# Altium schematic sheet receiver.SchDoc
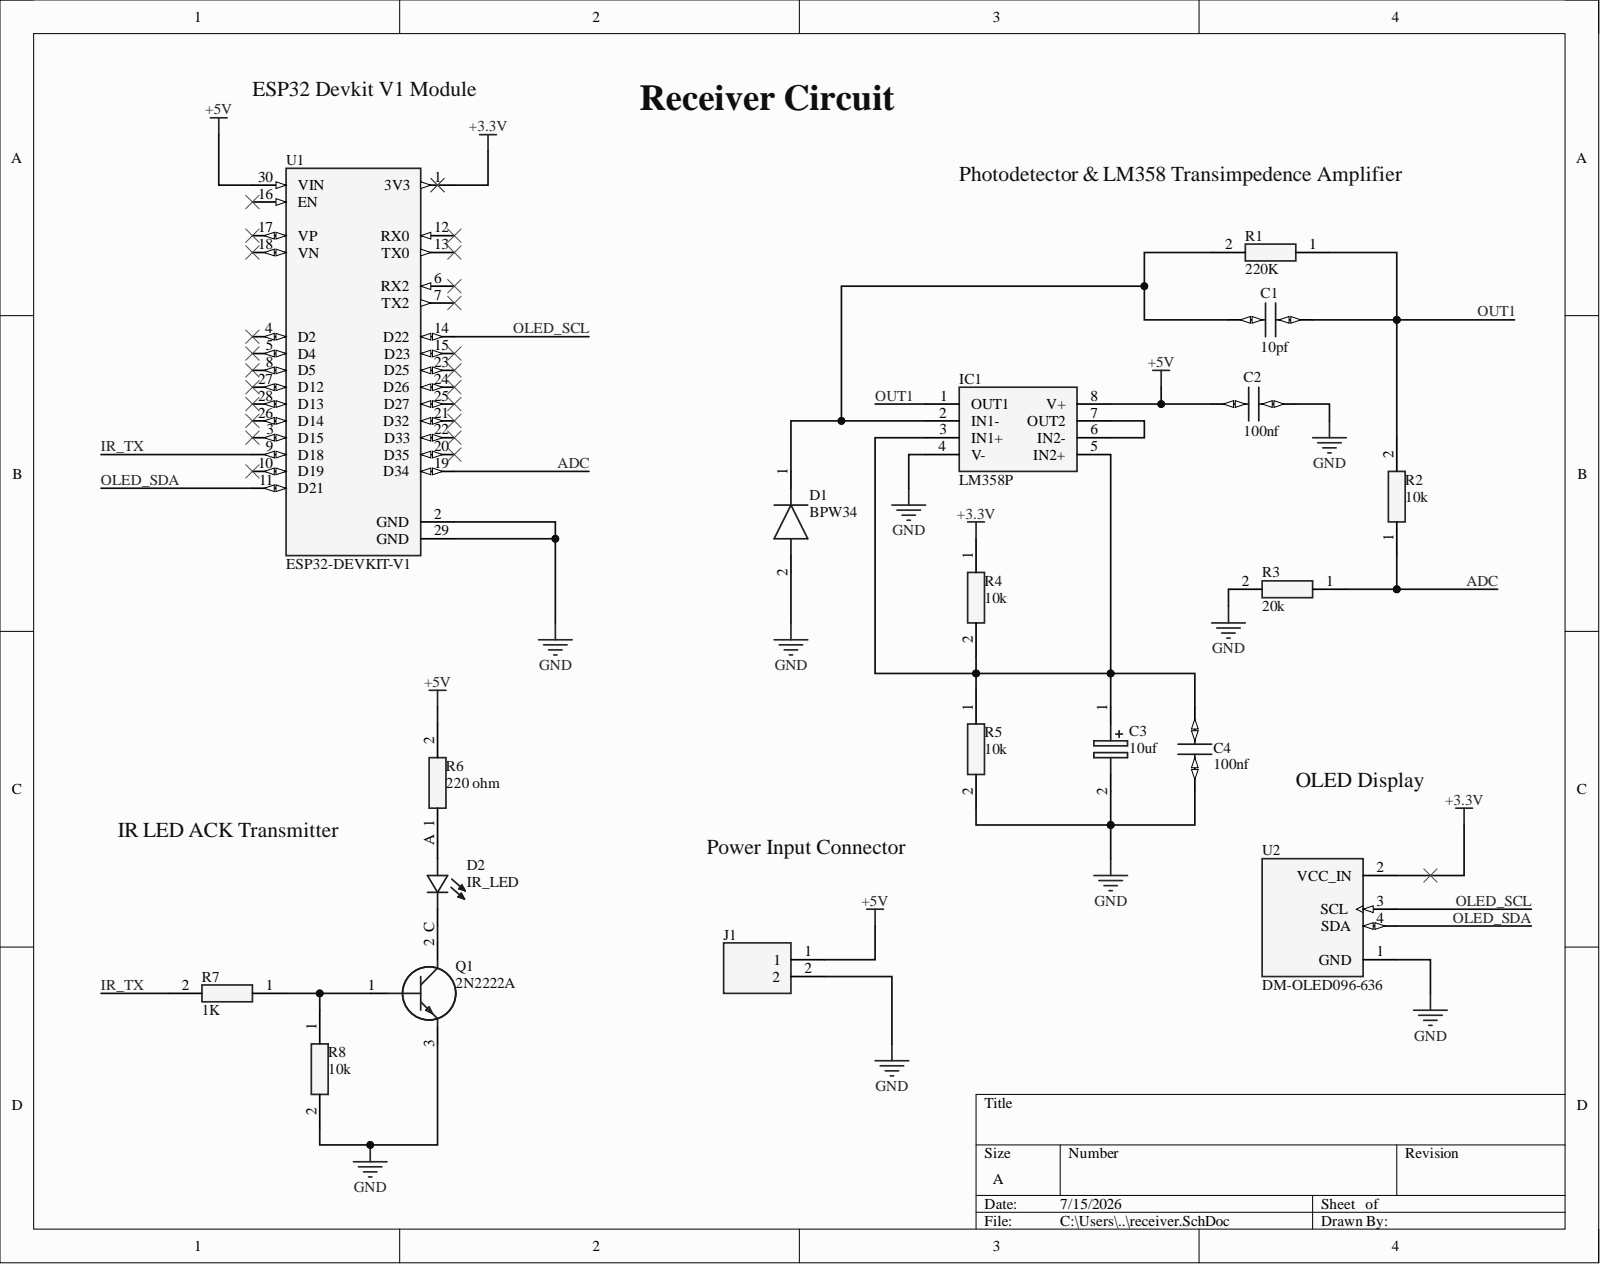
[diagram p0: receiver.SchDoc]

## Parts
C1  10pf  [C320C]
C2  100nf  [C320C]
C3  10uf  [CAPPRD350W65D800H1300]
C4  100nf  [C320C]
D1  BPW34  [BPW34]
D2  IR_LED  [LEDRD254W57D500H1070]
IC1  LM358P  [DIP794W53P254L959H508Q8N]
J1  282834-2  [TE_282834-2]  (value not drawn)
Q1  2N2222A  [2N2907A]
R1  220K  [resistor]
R2  10k  [resistor]
R3  20k  [resistor]
R4  10k  [resistor]
R5  10k  [resistor]
R6  220 ohm  [resistor]
R7  1K  [resistor]
R8  10k  [resistor]
U1  ESP32-DEVKIT-V1  [MODULE_ESP32_DEVKIT_V1]
U2  DM-OLED096-636  [MODULE_DM-OLED096-636]

## Nets
+3.3V: R4.1 U1.1(3V3) U2.2(VCC_IN)
+5V: C2.2 IC1.8(V+) J1.1 R6.2 U1.30(VIN)
GND: C2.1 C3.2 C4.1 D1.2 IC1.4(V-) J1.2 Q1.3 R3.2 R5.2 R8.2 U1.2(GND) U1.29(GND) U2.1(GND)
ADC: R2.1 R3.1 U1.19(D34)
IR_TX: R7.2 U1.9(D18)
OLED_SCL: U1.14(D22) U2.3(SCL)
OLED_SDA: U1.11(D21) U2.4(SDA)
OUT1: C1.1 IC1.1(OUT1) R1.1 R2.2
NetC1_2: C1.2 D1.1 IC1.2(IN1-) R1.2
NetC3_1: C3.1 C4.2 IC1.3(IN1+) IC1.5(IN2+) R4.2 R5.1
NetD2_A: D2.A R6.1
NetD2_C: D2.C Q1.2
NetIC1_6: IC1.6(IN2-) IC1.7(OUT2)
NetQ1_1: Q1.1 R7.1 R8.1

Not connected: U1 3(D15) 4(D2) 5(D4) 6(RX2) 7(TX2) 8(D5) 10(D19) 12(RX0) 13(TX0) 15(D23) 16(EN) 17(VP) 18(VN) 20(D35) 21(D32) 22(D33) 23(D25) 24(D26) 25(D27) 26(D14) 27(D12) 28(D13)

## Library data of the parts
C1 C2 C4 = C320C104M5U5TA: MF: KEMET; Check_prices: https://www.snapeda.com/parts/C320C104M5U5TA/KEMET/view-part/?ref=eda; Component Kind: Standard; Library Name: Schlib1.SchLib; MP: C320C104M5U5TA; Package: Radial KEMET; SnapEDA_Link: https://www.snapeda.com/parts/C320C104M5U5TA/KEMET/view-part/?ref=snap
C3 = 470uf: Capacitor Polarised; Manufacturer_Name: Panasonic; Manufacturer_Part_Number: EEU-FR1C471; Component Kind: Standard; Datasheet Link: http://industrial.panasonic.com/cdbs/www-data/pdf/RDF0000/ABA0000C1259.pdf; Height: 13mm; Library Name: Schlib1.SchLib; Mouser Part Number: 667-EEU-FR1C471; Mouser Price/Stock: https://www.mouser.co.uk/ProductDetail/Panasonic/EEU-FR1C471?qs=Uw8ySfEHS7KxXdGBPGtJqw%3D%3D
D1 = BPW34: Diode; Manufacturer_Name: ams OSRAM; Arrow Part Number: BPW34; Arrow Price/Stock: https://www.arrow.com/en/products/bpw34/osram-opto-semiconductors?region=europe; Component Kind: Standard; Datasheet Link: https://datasheet.datasheetarchive.com/originals/distributors/Datasheets-24/DSA-465527.pdf; Height: 2.2mm; Library Name: Schlib1.SchLib; Manufacturer_Part_Number: BPW34; Mouser Part Number: N/A; Mouser Price/Stock: https://www.mouser.com/Search/Refine.aspx?Keyword=N%2FA
D2 = L08R5000Q1: LED, 5MM, ORANGE; LED / Lamp Size: 5mm / T-1 3/4; LED Colour: Orange; Typ Luminous Intensity: 4.3mcd; Viewing Angle: ...; MF: LED Technology; Availability: In Stock; Check_prices: https://www.snapeda.com/parts/L08R5000Q1/LED+Technology/view-part/?ref=eda; Component Kind: Standard; Library Name: Schlib1.SchLib; MP: L08R5000Q1; Package: None; Price: None; SnapEDA_Link: https://www.snapeda.com/parts/L08R5000Q1/LED+Technology/view-part/?ref=snap
IC1 = LM358P: Integrated Circuit; Manufacturer_Name: Texas Instruments; Arrow Part Number: LM358P; Arrow Price/Stock: https://www.arrow.com/en/products/lm358p/texas-instruments.html?utm_currency=USD&region=nac; Component Kind: Standard; Datasheet Link: http://www.ti.com/lit/ds/symlink/lm358a.pdf; Height: 5.08mm; Library Name: Schlib1.SchLib; Manufacturer_Part_Number: LM358P; Mouser Part Number: 595-LM358P; Mouser Price/Stock: https://www.mouser.co.uk/ProductDetail/Texas-Instruments/LM358P?qs=X1HXWTtiZ0QtOTT8%252BVnsyw%3D%3D
J1 = 282834-2: Terminal Block Connector PCB 2.54 mm 30-16 AWG Green 10 A 150 V M1.6 | TE Connectivity 282834-2; Centerline_Pitch: 2.54 mm[.1 in]; Number_of_Positions: 2; Check_prices: https://www.snapeda.com/parts/282834-2/TE+Connectivity+AMP+Connectors/view-part/?ref=eda; Component Kind: Standard; EU_RoHS_Compliance: Compliant with Exemptions; Library Name: Schlib1.SchLib; MF: TE Connectivity; MP: 282834-2; Package: None; SnapEDA_Link: https://www.snapeda.com/parts/282834-2/TE+Connectivity+AMP+Connectors/view-part/?ref=snap
Q1 = 2N2222A: Transistor BJT NPN; Manufacturer_Name: STMicroelectronics; Component Kind: Standard; Datasheet Link: https://datasheet.datasheetarchive.com/originals/distributors/Datasheets-25/DSA-481035.pdf; Height: 5.3mm; Library Name: Schlib1.SchLib; Manufacturer_Part_Number: 2N2222A; Mouser Part Number: 511-2N2222A; Mouser Price/Stock: https://www.mouser.co.uk/ProductDetail/STMicroelectronics/2N2222A?qs=UMEuL5FsraBzcgM1cdcH3A%3D%3D
R1-R8 = 220ohm resistor throuh hole_1: Resistor; Manufacturer_Name: KEMET; Manufacturer_Part_Number: CFR-25JB-52-10K; Arrow Part Number: CFR-25JB-52-10K; Arrow Price/Stock: https://www.arrow.com/en/products/cfr-25jb-52-10k/yageo; Component Kind: Standard; Datasheet Link: https://datasheet.datasheetarchive.com/originals/distributors/Datasheets_SAMA/cf5c7c7b14e59499e9125003e6ee5ce2.pdf; Library Name: Schlib1.SchLib; Mouser Part Number: 603-CFR-25JB-52-10K; Mouser Price/Stock: https://www.mouser.co.uk/ProductDetail/YAGEO/CFR-25JB-52-10K?qs=oypCK0zG32646UD7yHncYg%3D%3D
U1 = ESP32-DEVKIT-V1: Dual core, Wi-Fi: 2.4 GHz up to 150 Mbits/s,BLE (Bluetooth Low Energy) and legacy Bluetooth, 32 bits, Up to 240 MHz; MF: Do it; Check_prices: https://www.snapeda.com/parts/ESP32-DEVKIT-V1/Do+it/view-part/?ref=eda; Component Kind: Standard; Library Name: Schlib1.SchLib; MP: ESP32-DEVKIT-V1; Package: None; SnapEDA_Link: https://www.snapeda.com/parts/ESP32-DEVKIT-V1/Do+it/view-part/?ref=snap
U2 = DM-OLED096-636: 0.96â€ 128 X 64 MONOCHROME GRAPHIC OLED DISPLAY MODULE - I2C; Check_prices: https://www.snapeda.com/parts/DM-OLED096-636/Display+Module/view-part/?ref=eda; Component Kind: Standard; Library Name: Schlib1.SchLib; MF: Display Module; MP: DM-OLED096-636; Package: Package; SnapEDA_Link: https://www.snapeda.com/parts/DM-OLED096-636/Display+Module/view-part/?ref=snap
